# Altium schematic sheet 变距桨控制板.SchDoc
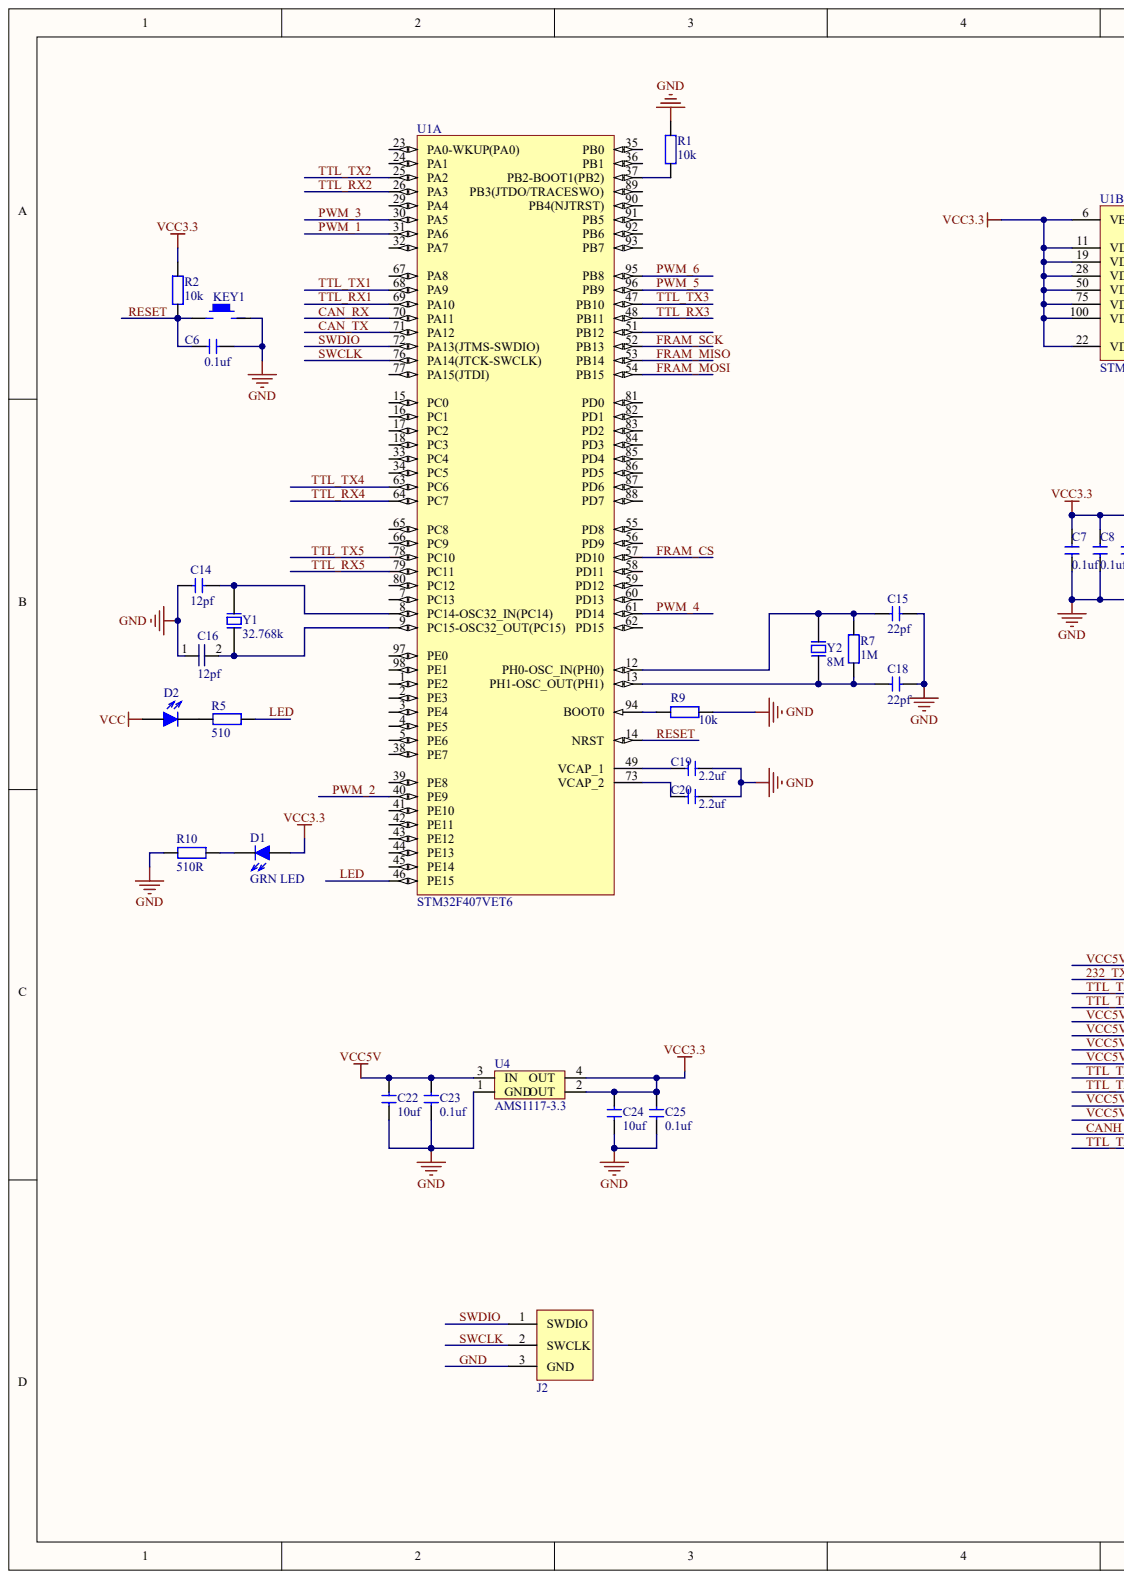
[diagram p0: 变距桨控制板.SchDoc, left]
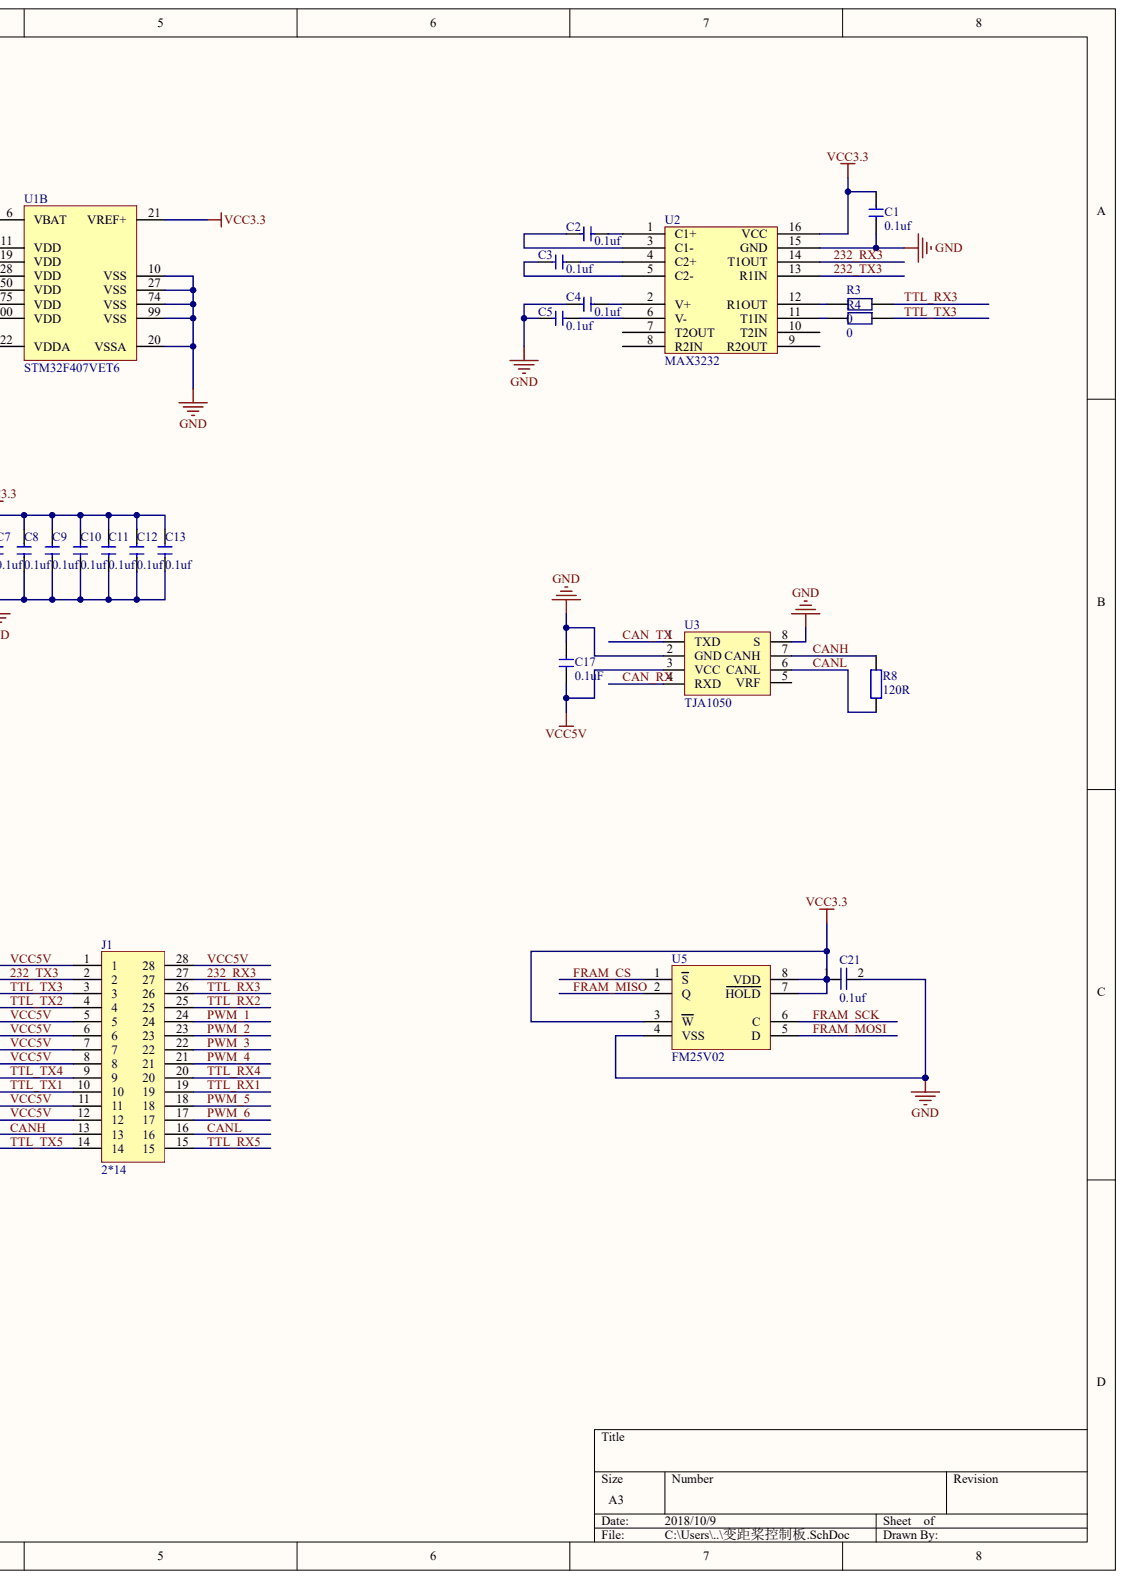
[diagram p1: 变距桨控制板.SchDoc, right]

## Parts
C1  0.1uf
C2  0.1uf
C3  0.1uf
C4  0.1uf
C5  0.1uf
C6  0.1uf
C7  0.1uf
C8  0.1uf
C9  0.1uf
C10  0.1uf
C11  0.1uf
C12  0.1uf
C13  0.1uf
C14  12pf
C15  22pf
C16  12pf
C17  0.1uF
C18  22pf
C19  2.2uf
C20  2.2uf
C21  0.1uf
C22  10uf
C23  0.1uf
C24  10uf
C25  0.1uf
D1  GRN LED
D2  GRN LED  (value not drawn)
J1  2*14
J2  烧写触点  (value not drawn)
KEY1  按键开关  (value not drawn)
R1  10k
R2  10k
R3  0
R4  0
R5  510
R7  1M
R8  120R
R9  10k
R10  510R
U1  STM32F407VET6  (parts A,B)
U2  MAX3232
U3  TJA1050
U4  AMS1117-3.3
U5  FM25V02
Y1  32.768k
Y2  8M

## Nets
VCC: D2.1
VCC3.3: C1.2 C7.2 C8.2 C9.2 C10.2 C11.2 C12.2 C13.2 C21.1 C24.2 C25.2 D1.1 R2.2 U1.6(VBAT) U1.11(VDD) U1.19(VDD) U1.21(VREF+) U1.22(VDDA) U1.28(VDD) U1.50(VDD) U1.75(VDD) U1.100(VDD) U2.16(VCC) U4.2(OUT) U4.4(OUT) U5.3(W) U5.7(HOLD) U5.8(VDD)
232_RX3: J1.27 U2.14(T1OUT)
232_TX3: J1.2 U2.13(R1IN)
CAN_RX: U1.70(PA11) U3.4(RXD)
CAN_TX: U1.71(PA12) U3.1(TXD)
CANH: J1.13 R8.2 U3.7(CANH)
CANL: J1.16 R8.1 U3.6(CANL)
FRAM_CS: U1.57(PD10) U5.1(S)
FRAM_MISO: U1.53(PB14) U5.2(Q)
FRAM_MOSI: U1.54(PB15) U5.5(D)
FRAM_SCK: U1.52(PB13) U5.6(C)
GND: C1.1 C4.1 C5.1 C6.1 C7.1 C8.1 C9.1 C10.1 C11.1 C12.1 C13.1 C14.2 C15.2 C16.1 C17.2 C18.2 C19.1 C20.1 C21.2 C22.1 C23.1 C24.1 C25.1 J2.3 KEY1.2 R1.2 R9.2 R10.1 U1.10(VSS) U1.20(VSSA) U1.27(VSS) U1.74(VSS) U1.99(VSS) U2.15(GND) U3.2(GND) U3.8(S) U4.1(GND) U5.4(VSS)
LED: R5.2 U1.46(PE15)
PWM_1: J1.24 U1.31(PA6)
PWM_2: J1.23 U1.40(PE9)
PWM_3: J1.22 U1.30(PA5)
PWM_4: J1.21 U1.61(PD14)
PWM_5: J1.18 U1.96(PB9)
PWM_6: J1.17 U1.95(PB8)
RESET: C6.2 KEY1.1 R2.1 U1.14(NRST)
SWCLK: J2.2 U1.76(PA14(JTCK-SWCLK))
SWDIO: J2.1 U1.72(PA13(JTMS-SWDIO))
TTL_RX1: J1.19 U1.69(PA10)
TTL_RX2: J1.25 U1.26(PA3)
TTL_RX3: J1.26 R3.2 U1.48(PB11)
TTL_RX4: J1.20 U1.64(PC7)
TTL_RX5: J1.15 U1.79(PC11)
TTL_TX1: J1.10 U1.68(PA9)
TTL_TX2: J1.4 U1.25(PA2)
TTL_TX3: J1.3 R4.2 U1.47(PB10)
TTL_TX4: J1.9 U1.63(PC6)
TTL_TX5: J1.14 U1.78(PC10)
VCC5V: C17.1 C22.2 C23.2 J1.1 J1.5 J1.6 J1.7 J1.8 J1.11 J1.12 J1.28 U3.3(VCC) U4.3(IN)
NetC2_1: C2.1 U2.3(C1-)
NetC2_2: C2.2 U2.1(C1+)
NetC3_1: C3.1 U2.5(C2-)
NetC3_2: C3.2 U2.4(C2+)
NetC4_2: C4.2 U2.2(V+)
NetC5_2: C5.2 U2.6(V-)
NetC14_1: C14.1 U1.8(PC14-OSC32_IN(PC14)) Y1.2
NetC15_1: C15.1 R7.1 U1.12(PH0-OSC_IN(PH0)) Y2.1
NetC16_2: C16.2 U1.9(PC15-OSC32_OUT(PC15)) Y1.1
NetC18_1: C18.1 R7.2 U1.13(PH1-OSC_OUT(PH1)) Y2.2
NetC19_2: C19.2 U1.49(VCAP_1)
NetC20_2: C20.2 U1.73(VCAP_2)
NetD1_2: D1.2 R10.2
NetD2_2: D2.2 R5.1
NetR1_1: R1.1 U1.37(PB2-BOOT1(PB2))
NetR3_1: R3.1 U2.12(R1OUT)
NetR4_1: R4.1 U2.11(T1IN)
NetR9_1: R9.1 U1.94(BOOT0)

Not connected: U1 1(PE2) 2(PE3) 3(PE4) 4(PE5) 5(PE6) 7(PC13) 15(PC0) 16(PC1) 17(PC2) 18(PC3) 23(PA0-WKUP(PA0)) 24(PA1) 29(PA4) 32(PA7) 33(PC4) 34(PC5) 35(PB0) 36(PB1) 38(PE7) 39(PE8) 41(PE10) 42(PE11) 43(PE12) 44(PE13) 45(PE14) 51(PB12) 55(PD8) 56(PD9) 58(PD11) 59(PD12) 60(PD13) 62(PD15) 65(PC8) 66(PC9) 67(PA8) 77(PA15(JTDI)) 80(PC12) 81(PD0) 82(PD1) 83(PD2) 84(PD3) 85(PD4) 86(PD5) 87(PD6) 88(PD7) 89(PB3(JTDO/TRACESWO)) 90(PB4(NJTRST)) 91(PB5) 92(PB6) 93(PB7) 97(PE0) 98(PE1); U2 7(T2OUT) 8(R2IN) 9(R2OUT) 10(T2IN); U3 5(VRF)

## Library data of the parts
U1 = (no symbol name): Manufacturer: STMicroelectronics; Core: ARM Cortex-M4; D/A Converter: 2x12-bit; FLASH Size (Prog) (kB): 512; I/Os (High Current): 82; Internal RAM Size (kB): 192; Operating Frequency(F) (Processor speed) (MHz): 168; Packing: Tray; Supply Voltage(Vcc) max (V): 3.6; Supply Voltage(Vcc) min (V): 1.8; DatasheetVersion: Rev. 4; PackageVersion: Rev. 1, 06/2013; PackageReference: LQFP100; PackageDescription: 100-Pin Low Profile Quad Flat Package, 14 x 14 mm Body, 0.5 mm Pitch; Supplier 1: Digi-Key; Supplier Part Number 1: 497-12075-ND; Supplier 2: Farnell; Supplier Part Number 2: 2064367; Supplier 3: Newark; Supplier Part Number 3: 73T2332; Supplier 4: Mouser; Supplier Part Number 4: 511-STM32F407VET6; Mounting Technology: Surface Mount; PartNumber: STM32F407VET6; ComponentLink1Description: Manufacturer URL; ComponentLink1URL: http://www.st.com/web/en/home.html; ComponentLink2Description: Datasheet; ComponentLink2URL: http://www.st.com/st-web-ui/static/active/en/resource/technical/document/datasheet/DM00037051.pdf; ComponentLink3Description: Package Specification; ComponentLink3URL: http://www.st.com/st-web-ui/static/active/en/resource/technical/document/data_brief/DM00071938.pdf
